# Altium schematic sheet POWER.SchDoc(POWER)
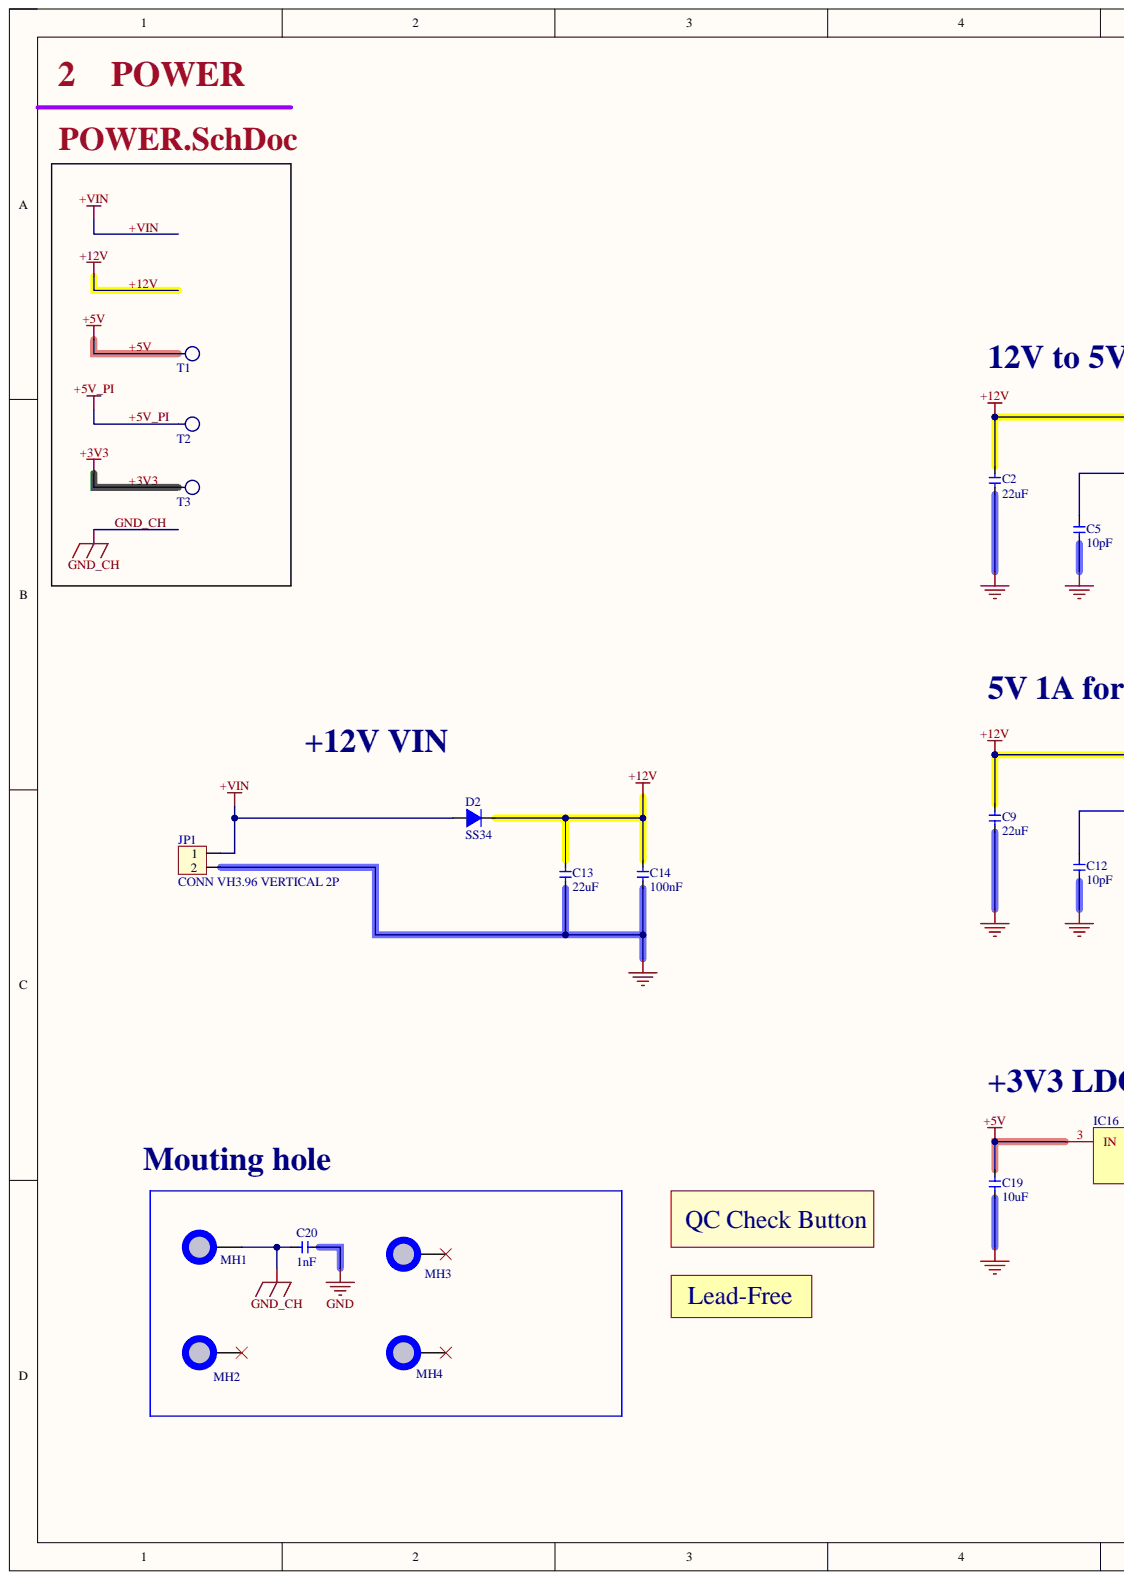
[diagram p0: POWER.SchDoc, left]
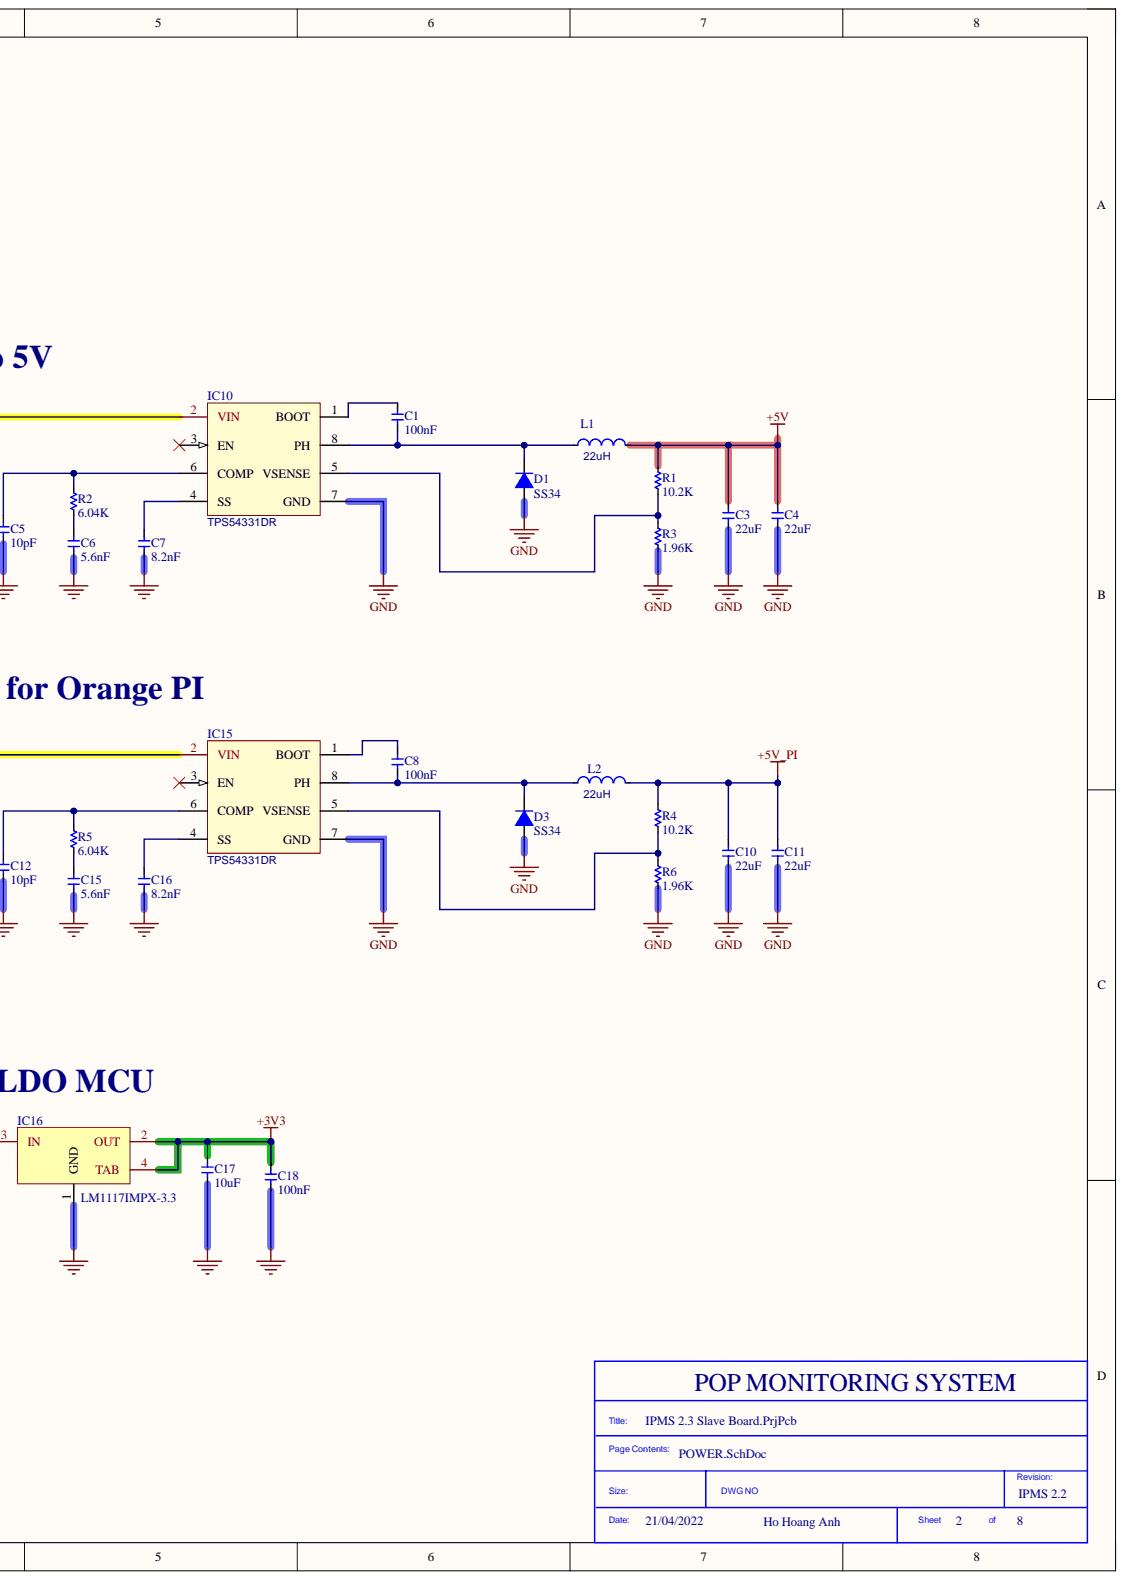
[diagram p1: POWER.SchDoc, right]

## Parts
C1  100nF  [C_0603]
C2  22uF  [C_1206]
C3  22uF  [C_1206]
C4  22uF  [C_1206]
C5  10pF  [C_0603]
C6  5.6nF  [C_0603]
C7  8.2nF  [C_0603]
C8  100nF  [C_0603]
C9  22uF  [C_1206]
C10  22uF  [C_1206]
C11  22uF  [C_1206]
C12  10pF  [C_0603]
C13  22uF  [C_1206]
C14  100nF  [C_0603]
C15  5.6nF  [C_0603]
C16  8.2nF  [C_0603]
C17  10uF  [C_0805]
C18  100nF  [C_0603]
C19  10uF  [C_0805]
C20  1nF  [C_1206]
D1  SS34  [SMA,DO-214AC]
D2  SS34  [SMA,DO-214AC]
D3  SS34  [SMA,DO-214AC]
IC10  TPS54331DR  [SOIC-8_3.9x4.9x1.27P]
IC15  TPS54331DR  [SOIC-8_3.9x4.9x1.27P]
IC16  LM1117IMPX-3.3  [SOT-223]
JP1  CONN VH3.96 VERTICAL 2P  [B2P-VH]
L1  22uH  [IND-SMD_L7.1-W6.6]
L2  22uH  [IND-SMD_L7.1-W6.6]
Lead_Free1  Lead Free  [LEAD_FREE_S]  (no BOM)
MH1  MH_6.0MMC_3.5MMD  [MH_6.0MMD_3.5MMD]  (value not drawn)  (no BOM)
MH2  MH_6.0MMC_3.5MMD  [MH_6.0MMD_3.5MMD]  (value not drawn)  (no BOM)
MH3  MH_6.0MMC_3.5MMD  [MH_6.0MMD_3.5MMD]  (value not drawn)  (no BOM)
MH4  MH_6.0MMC_3.5MMD  [MH_6.0MMD_3.5MMD]  (value not drawn)  (no BOM)
QC1  QC_Check_Button  [QC_CHECK_BUTTON]  (no BOM)
R1  10.2K  [R_0603]
R2  6.04K  [R_0603]
R3  1.96K  [R_0603]
R4  10.2K  [R_0603]
R5  6.04K  [R_0603]
R6  1.96K  [R_0603]
T1  TEST-POINT'4545'  [TP-D45MIL]  (value not drawn)  (no BOM)
T2  TEST-POINT'4545'  [TP-D45MIL]  (value not drawn)  (no BOM)
T3  TEST-POINT'4545'  [TP-D45MIL]  (value not drawn)  (no BOM)

## Nets
GND: C2.1 C3.1 C4.1 C5.1 C6.1 C7.1 C9.1 C10.1 C11.1 C12.1 C13.1 C14.1 C15.1 C16.1 C17.1 C18.1 C19.1 C20.2 D1.1 D3.1 IC10.7(GND) IC15.7(GND) IC16.1(GND) JP1.2 R3.2 R6.2
+3V3: C17.2 C18.2 IC16.2(OUT) IC16.4(TAB) T3.T
+5V: C3.2 C4.2 C19.2 IC16.3(IN) L1.2 R1.1 T1.T
+5V_PI: C10.2 C11.2 L2.2 R4.1 T2.T
+12V: C2.2 C9.2 C13.2 C14.2 D2.2 IC10.2(VIN) IC15.2(VIN)
+VIN: D2.1 JP1.1
GND_CH: C20.1 MH1.1
NetC1_1: C1.1 D1.2 IC10.8(PH) L1.1
NetC1_2: C1.2 IC10.1(BOOT)
NetC5_2: C5.2 IC10.6(COMP) R2.1
NetC6_2: C6.2 R2.2
NetC7_2: C7.2 IC10.4(SS)
NetC8_1: C8.1 D3.2 IC15.8(PH) L2.1
NetC8_2: C8.2 IC15.1(BOOT)
NetC12_2: C12.2 IC15.6(COMP) R5.1
NetC15_2: C15.2 R5.2
NetC16_2: C16.2 IC15.4(SS)
NetIC10_5: IC10.5(VSENSE) R1.2 R3.1
NetIC15_5: IC15.5(VSENSE) R4.2 R6.1

Not connected: IC10 3(EN); IC15 3(EN); MH2 1; MH3 1; MH4 1

## Library data of the parts
C1 C8 C14 C18 = CC0603KRX7R9BB104: 100nF 50V X7R ±10% 0603 Multilayer Ceramic Capacitors MLCC - SMD/SMT ROHS; Manufacturer: YAGEO; Assembly Type: SMT Assembly; Component Kind: Standard; LCSC Part Number: C14663; Manufacturer Part Number: CC0603KRX7R9BB104; Package: 0603; Library Name: IPMS 2.3 Slave Board.SCHLIB
C2-C4 C9-C11 C13 = CL31A226KAHNNNE: 22UF 25V 1206 ROHS; Manufacturer: Samsung Electro-Mechanics; Assembly Type: SMT Assembly; Component Kind: Standard; LCSC Part Number: C12891; Manufacturer Part Number: CL31A226KAHNNNE; Package: 1206; Library Name: IPMS 2.3 Slave Board.SCHLIB
C5 C12 = CL10C100JB8NNNC: 10PF 50V 0603 ROHS; Manufacturer: Samsung Electro-Mechanics; Assembly Type: SMT Assembly; Component Kind: Standard; LCSC Part Number: C1634; Manufacturer Part Number: CL10C100JB8NNNC; Package: 0603; Library Name: IPMS 2.3 Slave Board.SCHLIB
C6 C15 = CL10B562KB8NNNC: 5.6nF 50V X7R ±10% 0603 Multilayer Ceramic Capacitors MLCC - SMD/SMT ROHS; Manufacturer: Samsung Electro-Mechanics; Assembly Type: SMT Assembly; Component Kind: Standard; LCSC Part Number: C170143; Manufacturer Part Number: CL10B562KB8NNNC; Package: 0603; Library Name: IPMS 2.3 Slave Board.SCHLIB
C7 C16 = CL10B822KB8NNNC: 8.2nF 50V 0603 ROHS; Manufacturer: Samsung Electro-Mechanics; Assembly Type: SMT Assembly; Component Kind: Standard; LCSC Part Number: C27920; Manufacturer Part Number: CL10B822KB8NNNC; Package: 0603; Library Name: IPMS 2.3 Slave Board.SCHLIB
C17 C19 = CL21A106KAYNNNE: 10UF 25V 0805 ROHS; Manufacturer: Samsung Electro-Mechanics; Assembly Type: SMT Assembly; Component Kind: Standard; LCSC Part Number: C15850; Manufacturer Part Number: CL21A106KAYNNNE; Package: 0805; Library Name: IPMS 2.3 Slave Board.SCHLIB
C20 = CC1206JKNPOCBN102: 1nF ±5% 1kV NP0 1206 Multilayer Ceramic Capacitors MLCC - SMD/SMT RoHS; Manufacturer: YAGEO; Assembly Type: SMT Assembly; Component Kind: Standard; LCSC Part Number: C113872; Manufacturer Part Number: CC1206JKNPOCBN102; Package: 1206; Library Name: IPMS 2.3 Slave Board.SCHLIB
D1-D3 = SS34: DIODE SCHOTTKY 40V 3A DO214AB; Manufacturer: MDD)icrodiode Electronics); Assembly Type: SMT Assembly; Component Kind: Standard; LCSC Part Number: C8678; Manufacturer Part Number: SS34; Package: SMA,DO-214AC; Library Name: IPMS 2.3 Slave Board.SCHLIB
IC10 IC15 = TPS54331DR: TPS54331DR 3A 28V SOIC-8_150mil DC-DC Converters ROHS; Manufacturer: Texas Instruments; Assembly Type: SMT Assembly; Component Kind: Standard; LCSC Part Number: C9865; Manufacturer Part Number: TPS54331DR; Package: SOIC-8_3.9x4.9x1.27P; Library Name: IPMS 2.3 Slave Board.SCHLIB
IC16 = LM1117IMPX-3.3: LOW DROPOUT REGULATORS(LDO) POSITIVE FIXED 1.2V @ 800MA 15V 3.3V 800MA SOT-223 ROHS; Manufacturer: Texas Instruments; Manufacturer Part Number: LM1117IMPX-3.3/NOPB; Assembly Type: SMT Assembly; Component Kind: Standard; LCSC Part Number: C23984; Package: SOT-223; Library Name: IPMS 2.3 Slave Board.SCHLIB
JP1 = VH3.96_2P: CONN HEADER VERTICAL 2POS 3.96MM; Manufacturer: JST; Manufacturer Part Number: B2P-VH(LF)(SN); Assembly Type: Hand Soldering; Component Kind: Standard; LCSC Part Number: N/A; Package: P=3.96; Library Name: IPMS 2.3 Slave Board.SCHLIB
L1 L2 = IND_SLO0630H220MTT: 22uH ±20% SMD Power Inductors ROHS; Manufacturer: Sunltech Tech; Assembly Type: SMT Assembly; Component Kind: Standard; LCSC Part Number: C207843; Manufacturer Part Number: SLO0630H220MTT; Package: SMD_7.1x6.6x3mm; Library Name: IPMS 2.3 Slave Board.SCHLIB
MH1-MH4 = MH_6.0MMC_3.5MMD: Mounting Hole 6.0mm Diameter, 3.5mm Drill; .Creator: MM; Library Name: IPMS 2.3 Slave Board.SchLib
R1 R4 = 0603WAF1022T5E: 10.2kΩ 0603 Chip Resistor - Surface Mount ROHS   ±1% 1/10W Thick Film Resistors 75V ±100ppm/℃ -55℃~+155℃; Manufacturer: Uniroyal Elec; Assembly Type: SMT Assembly; Component Kind: Standard; LCSC Part Number: C22772; Manufacturer Part Number: 0603WAF1022T5E; Package: 0603; Library Name: IPMS 2.3 Slave Board.SCHLIB
R2 R5 = 0603WAF6041T5E: 6.04kΩ 0603 Chip Resistor - Surface Mount ROHS; Manufacturer: Uniroyal Elec; Assembly Type: SMT Assembly; Component Kind: Standard; LCSC Part Number: C25977; Manufacturer Part Number: 0603WAF6041T5E; Package: 0603; Library Name: IPMS 2.3 Slave Board.SCHLIB
R3 R6 = 0603WAF1961T5E: 1.96kΩ 0603 Chip Resistor - Surface Mount ROHS   ±1% 1/10W Thick Film Resistors 75V ±100ppm/℃ -55℃~+155℃; Manufacturer: Uniroyal Elec; Assembly Type: SMT Assembly; Component Kind: Standard; LCSC Part Number: C22854; Manufacturer Part Number: 0603WAF1961T5E; Package: 0603; Library Name: IPMS 2.3 Slave Board.SCHLIB
T1-T3 = TEST-POINT'4545': Manufacturer: No; Description: TEST-POINT'4545'; LCSC Part Number: No; Manufacturer Part Number: No; Package: No; Library Name: IPMS 2.3 Slave Board.SchLib
Lead_Free1 = Lead Free: Description: Lead Free; Library Name: Schlib IPMS 2.3.SchLib
QC1 = QC_Check_Button: Description: QC_Check_Button; Library Name: Schlib IPMS 2.3.SchLib
